# Altium schematic sheet 17_ATK_RK3588S_EMMC_SD.SchDoc
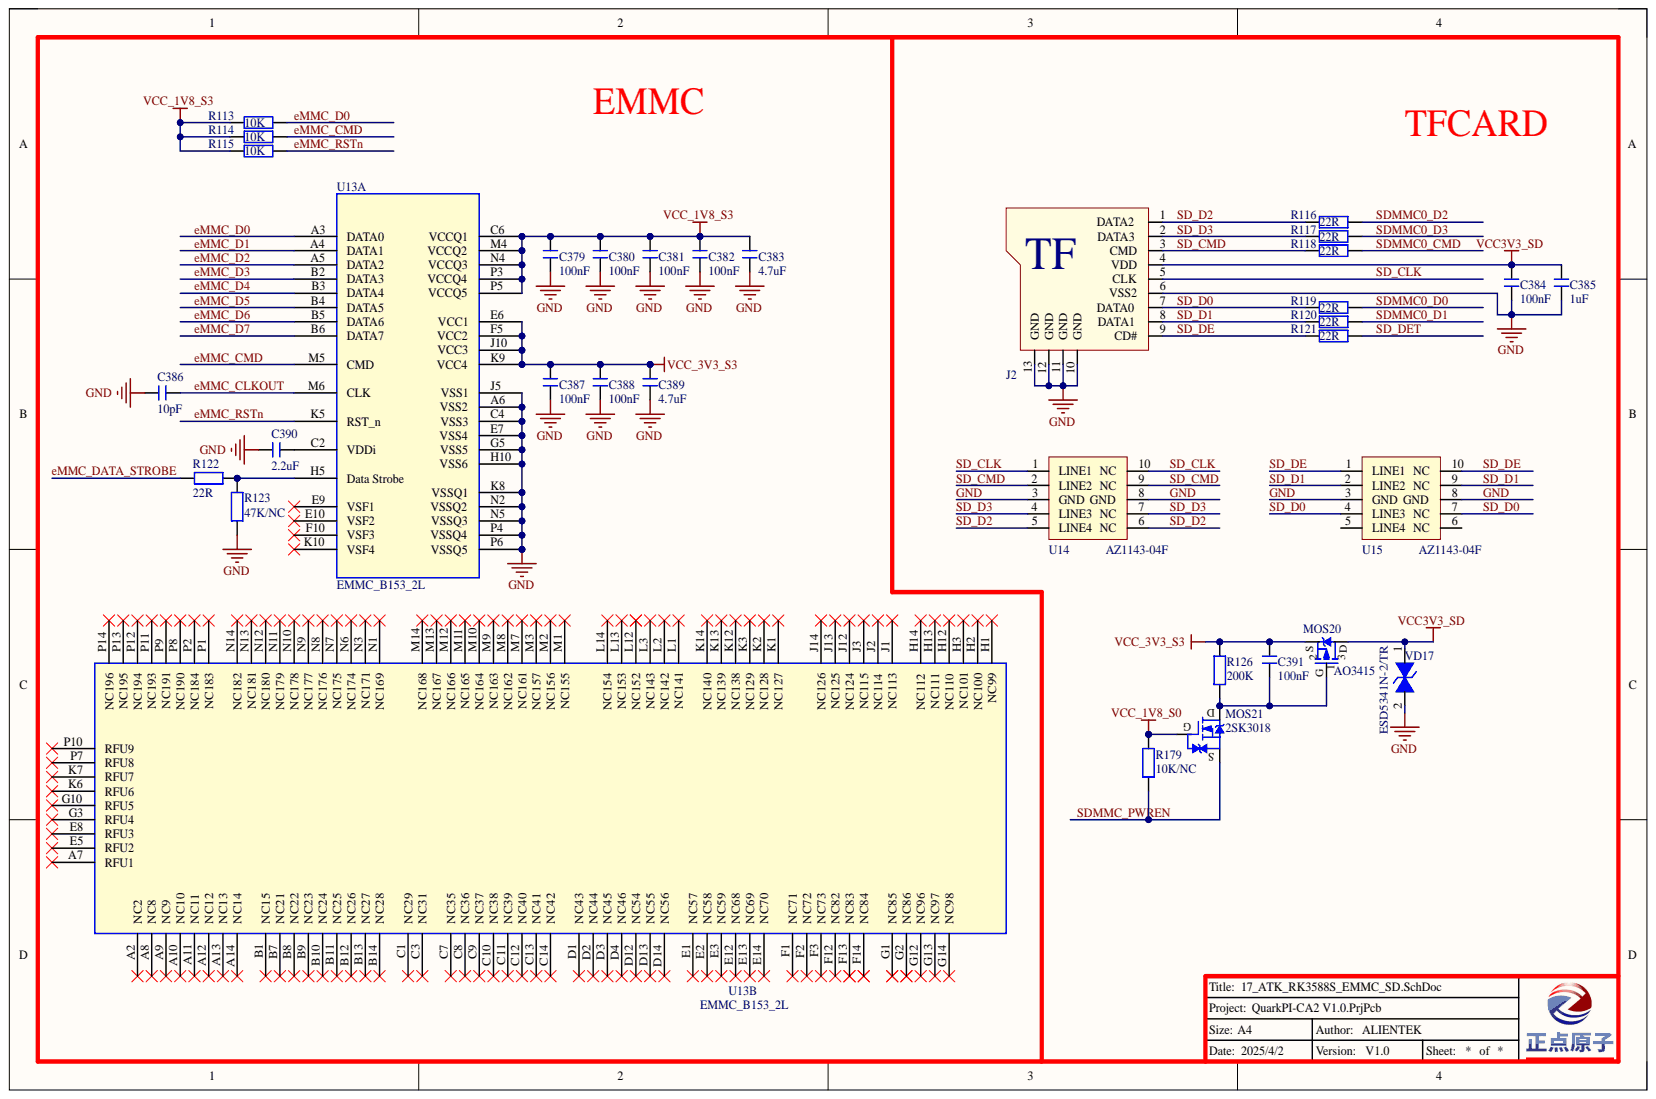
[diagram p0: 17_ATK_RK3588S_EMMC_SD.SchDoc]

## Parts
C379  100nF  [C 0201]
C380  100nF  [C 0201]
C381  100nF  [C 0201]
C382  100nF  [C 0201]
C383  4.7uF  [C 0402]
C384  100nF  [C 0201]
C385  1uF  [C 0201]
C386  10pF  [C 0201]
C387  100nF  [C 0201]
C388  100nF  [C 0201]
C389  4.7uF  [C 0402]
C390  2.2uF  [C 0402]
C391  100nF  [C 0402]
J2  SD-MICRO-TF  [SD-MICRO-TF]  (value not drawn)
MOS20  AO3415  [SOT23-3N]
MOS21  2SK3018  [SOT323-3L]
R113  10K  [R 0201]
R114  10K  [R 0201]
R115  10K  [R 0201]
R116  22R  [R 0402]
R117  22R  [R 0402]
R118  22R  [R 0402]
R119  22R  [R 0402]
R120  22R  [R 0402]
R121  22R  [R 0402]
R122  22R  [R 0201]
R123  47K/NC  [R 0402]
R126  200K  [R 0402]
R179  10K/NC  [R 0402]
U13  EMMC_B153_2L  [BGA153_34R0X34R0X45R75_S]  (parts A,B)
U14  AZ1143-04F  [DFN2510P10E]
U15  AZ1143-04F  [DFN2510P10E]
VD17  ESD5341N-2/TR  [ESD0402]

## Nets
VCC3V3_SD: C384.2 C385.2 J2.4(VDD) MOS20.3 VD17.1
VCC_1V8_S0: MOS21.1 R179.1
VCC_1V8_S3: C379.2 C380.2 C381.2 C382.2 C383.2 R113.2 R114.2 R115.2 U13.C6(VCCQ1) U13.M4(VCCQ2) U13.N4(VCCQ3) U13.P3(VCCQ4) U13.P5(VCCQ5)
VCC_3V3_S3: C387.2 C388.2 C389.2 C391.2 MOS20.2 R126.1 U13.E6(VCC1) U13.F5(VCC2) U13.J10(VCC3) U13.K9(VCC4)
eMMC_CLKOUT: C386.2 U13.M6(CLK)
eMMC_CMD: R114.1 U13.M5(CMD)
eMMC_D0: R113.1 U13.A3(DATA0)
eMMC_D1: U13.A4(DATA1)
eMMC_D2: U13.A5(DATA2)
eMMC_D3: U13.B2(DATA3)
eMMC_D4: U13.B3(DATA4)
eMMC_D5: U13.B4(DATA5)
eMMC_D6: U13.B5(DATA6)
eMMC_D7: U13.B6(DATA7)
eMMC_DATA_STROBE: R122.2
eMMC_RSTn: R115.1 U13.K5(RST_n)
GND: C379.1 C380.1 C381.1 C382.1 C383.1 C384.1 C385.1 C386.1 C387.1 C388.1 C389.1 C390.2 J2.6(VSS2) J2.10(GND) J2.11(GND) J2.12(GND) J2.13(GND) R123.2 U13.A6(VSS2) U13.C4(VSS3) U13.E7(VSS4) U13.G5(VSS5) U13.H10(VSS6) U13.J5(VSS1) U13.K8(VSSQ1) U13.N2(VSSQ2) U13.N5(VSSQ3) U13.P4(VSSQ4) U13.P6(VSSQ5) U14.3(GND) U14.8(GND) U15.3(GND) U15.8(GND) VD17.2
SD_CLK: J2.5(CLK) U14.1(LINE1) U14.10(NC)
SD_CMD: J2.3(CMD) R118.1 U14.2(LINE2) U14.9(NC)
SD_D0: J2.7(DATA0) R119.1 U15.4(LINE3) U15.7(NC)
SD_D1: J2.8(DATA1) R120.1 U15.2(LINE2) U15.9(NC)
SD_D2: J2.1(DATA2) R116.1 U14.5(LINE4) U14.6(NC)
SD_D3: J2.2(DATA3) R117.1 U14.4(LINE3) U14.7(NC)
SD_DE: J2.9(CD#) R121.1 U15.1(LINE1) U15.10(NC)
SD_DET: R121.2
SDMMC0_CMD: R118.2
SDMMC0_D0: R119.2
SDMMC0_D1: R120.2
SDMMC0_D2: R116.2
SDMMC0_D3: R117.2
SDMMC_PWREN: MOS21.2 R179.2
NetC390_1: C390.1 U13.C2(VDDi)
NetC391_1: C391.1 MOS20.1 MOS21.3 R126.2
NetR122_1: R122.1 R123.1 U13.H5(Data_Strobe)

Not connected: U13 A2(NC2) A7(RFU1) A8(NC8) A9(NC9) A10(NC10) A11(NC11) A12(NC12) A13(NC13) A14(NC14) B1(NC15) B7(NC21) B8(NC22) B9(NC23) B10(NC24) B11(NC25) B12(NC26) B13(NC27) B14(NC28) C1(NC29) C3(NC31) C7(NC35) C8(NC36) C9(NC37) C10(NC38) C11(NC39) C12(NC40) C13(NC41) C14(NC42) D1(NC43) D2(NC44) D3(NC45) D4(NC46) D12(NC54) D13(NC55) D14(NC56) E1(NC57) E2(NC58) E3(NC59) E5(RFU2) E8(RFU3) E9(VSF1) E10(VSF2) E12(NC68) E13(NC69) E14(NC70) F1(NC71) F2(NC72) F3(NC73) F10(VSF3) F12(NC82) F13(NC83) F14(NC84) G1(NC85) G2(NC86) G3(RFU4) G10(RFU5) G12(NC96) G13(NC97) G14(NC98) H1(NC99) H2(NC100) H3(NC101) H12(NC110) H13(NC111) H14(NC112) J1(NC113) J2(NC114) J3(NC115) J12(NC124) J13(NC125) J14(NC126) K1(NC127) K2(NC128) K3(NC129) K6(RFU6) K7(RFU7) K10(VSF4) K12(NC138) K13(NC139) K14(NC140) L1(NC141) L2(NC142) L3(NC143) L12(NC152) L13(NC153) L14(NC154) M1(NC155) M2(NC156) M3(NC157) M7(NC161) M8(NC162) M9(NC163) M10(NC164) M11(NC165) M12(NC166) M13(NC167) M14(NC168) N1(NC169) N3(NC171) N6(NC174) N7(NC175) N8(NC176) N9(NC177) N10(NC178) N11(NC179) N12(NC180) N13(NC181) N14(NC182) P1(NC183) P2(NC184) P7(RFU8) P8(NC190) P9(NC191) P10(RFU9) P11(NC193) P12(NC194) P13(NC195) P14(NC196); U15 5(LINE4) 6(NC)

## Library data of the parts
C379-C391 = C: 无极性电容; BOM名称: 无极性贴片电容; 版权归属: 正点原子; Component Kind: Standard; Library Name: 正点原子封装库V1.0.IntLib; 学习资源: www.openedv.com
J2 = SD-MICRO-TF: SD,MICRO,TF; Component Kind: Standard; Library Name: 正点原子封装库V1.0.IntLib
MOS20 = AO3415: PMOS -20V-4.3A 45mΩ; BOM名称: P沟道场效应管; Parameter: MOS管; 版权归属: 正点原子; Component Kind: Standard; 学习资源: www.openedv.com; Library Name: Lycreturn.IntLib
MOS21 = 2SK3018: NMOS  30V 0.1A@2.5V 8Ω; BOM名称: N沟场效应管; Parameter: MOS管; 版权归属: 正点原子; Component Kind: Standard; Library Name: ATK-DLRK3588B V0.2.IntLib; 学习资源: www.openedv.com
R113-R123 R126 R179 = R: 电阻; BOM名称: 贴片电阻; 版权归属: 正点原子; Component Kind: Standard; Library Name: 正点原子封装库V1.0.IntLib; Parameter: 电阻; 学习资源: www.openedv.com
U13 = INAND_EMMC_153_2L: EMMC_B153_2L,更好的满足工艺需求，Fanout方式部分走线跨接再球位上，挖除A1\C5球位; Priority: A; Component Kind: Standard; Part Type: NAND_FLASH; RK PN: EMMC_B153_2L; Created by: YWQ; Library Name: atompi-ca2.SchLib
U14 U15 = AZ1143-04F: ESD保护二极管阵列; Component Kind: Standard; Library Name: ATK-DLRK3588B V0.2.IntLib
VD17 = ESD5341N: ESD二极管-单向; Component Kind: Standard; Library Name: ZZK_COMPONENT.IntLib
